# Altium schematic sheet Analog.SchDoc
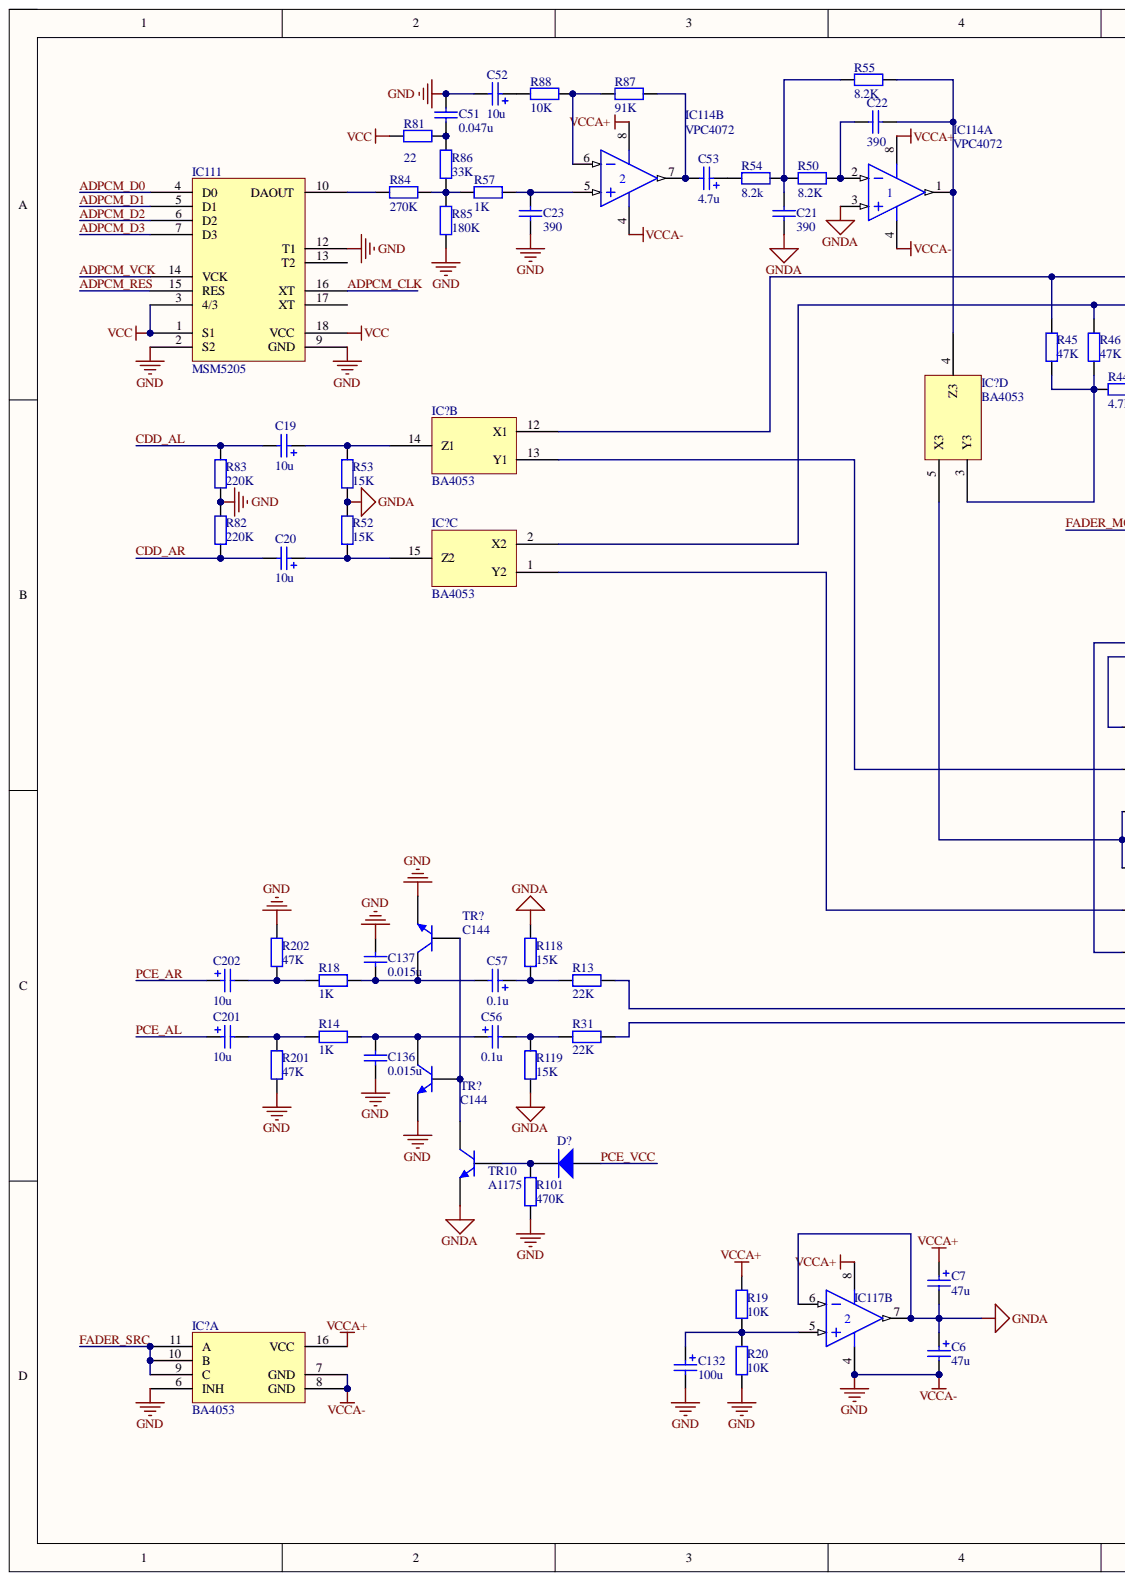
[diagram p0: Analog.SchDoc, left]
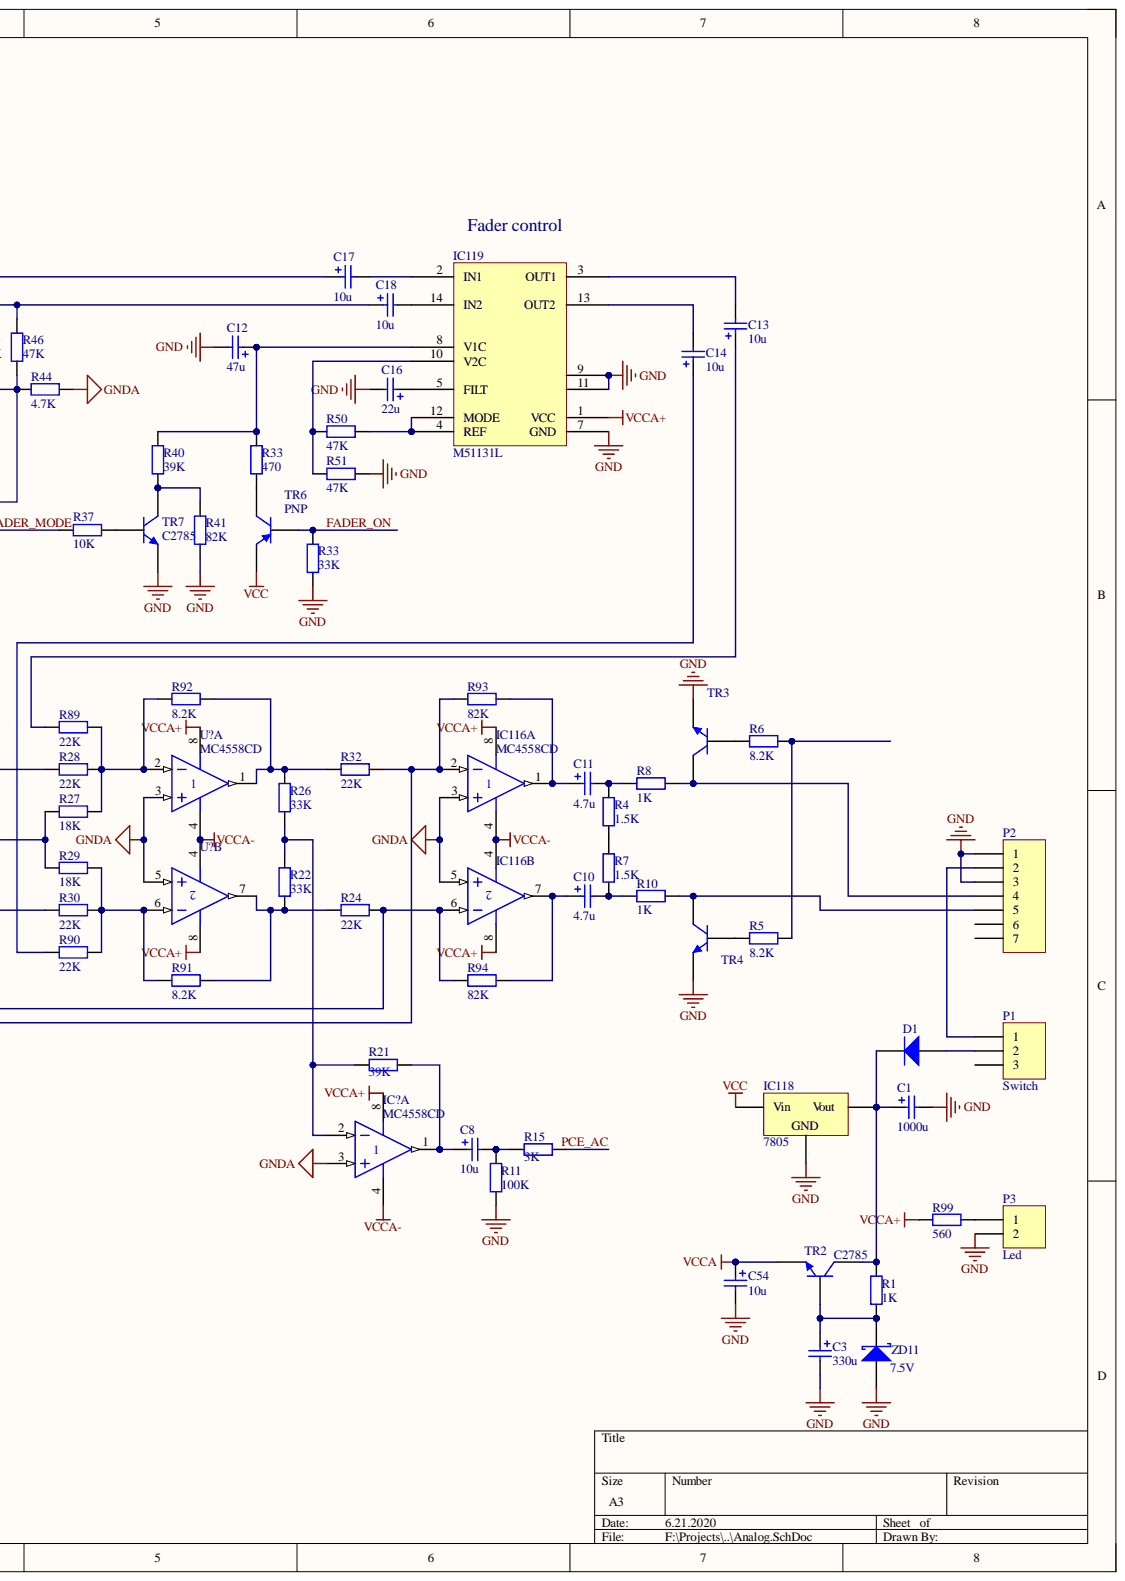
[diagram p1: Analog.SchDoc, right]

## Parts
C1  value 1000u  [POLAR0.8]  (hidden comment: Cap Pol2)
C3  value 330u  [POLAR0.8]  (hidden comment: Cap Pol2)
C6  value 47u  [POLAR0.8]  (hidden comment: Cap Pol2)
C7  value 47u  [POLAR0.8]  (hidden comment: Cap Pol2)
C8  value 10u  [POLAR0.8]  (hidden comment: Cap Pol2)
C10  value 4.7u  [POLAR0.8]  (hidden comment: Cap Pol2)
C11  value 4.7u  [POLAR0.8]  (hidden comment: Cap Pol2)
C12  value 47u  [POLAR0.8]  (hidden comment: Cap Pol2)
C13  value 10u  [POLAR0.8]  (hidden comment: Cap Pol2)
C14  value 10u  [POLAR0.8]  (hidden comment: Cap Pol2)
C16  value 22u  [POLAR0.8]  (hidden comment: Cap Pol2)
C17  value 10u  [POLAR0.8]  (hidden comment: Cap Pol2)
C18  value 10u  [POLAR0.8]  (hidden comment: Cap Pol2)
C19  value 10u  [POLAR0.8]  (hidden comment: Cap Pol2)
C20  value 10u  [POLAR0.8]  (hidden comment: Cap Pol2)
C21  value 390  [C1206]  (hidden comment: Cap Semi)
C22  value 390  [C1206]  (hidden comment: Cap Semi)
C23  value 390  [C1206]  (hidden comment: Cap Semi)
C51  value 0.047u  [C1206]  (hidden comment: Cap Semi)
C52  value 10u  [POLAR0.8]  (hidden comment: Cap Pol2)
C53  value 4.7u  [POLAR0.8]  (hidden comment: Cap Pol2)
C54  value 10u  [POLAR0.8]  (hidden comment: Cap Pol2)
C56  value 0.1u  [POLAR0.8]  (hidden comment: Cap Pol2)
C57  value 0.1u  [POLAR0.8]  (hidden comment: Cap Pol2)
C132  value 100u  [POLAR0.8]  (hidden comment: Cap Pol2)
C136  value 0.015u  [C1206]  (hidden comment: Cap Semi)
C137  value 0.015u  [C1206]  (hidden comment: Cap Semi)
C201  value 10u  [POLAR0.8]  (hidden comment: Cap Pol2)
C202  value 10u  [POLAR0.8]  (hidden comment: Cap Pol2)
D1  Diode 1N4148  [DO-35]  (value not drawn)
D?  Diode 1N4148  [DO-35]  (value not drawn)
IC111  MSM5205
IC114  VPC4072  [751-02_N]  (parts A,B)
IC116  MC4558CD  [751-02_N]  (parts A,B)
IC117  MC4558CD  [751-02_N]  (part B)
IC118  7805  [D2PAK_N]
IC119  M51131L
IC?  MC4558CD  [751-02_N]  (parts A,B,C,D)
IC?  BA4053  (part A)
P1  Switch  [HDR1X3]
P2  Header 7  [HDR1X7]  (value not drawn)
P3  Led  [HDR1X2H]
R1  value 1K  [AXIAL-0.4]  (hidden comment: Res2)
R4  value 1.5K  [AXIAL-0.4]  (hidden comment: Res2)
R5  value 8.2K  [AXIAL-0.4]  (hidden comment: Res2)
R6  value 8.2K  [AXIAL-0.4]  (hidden comment: Res2)
R7  value 1.5K  [AXIAL-0.4]  (hidden comment: Res2)
R8  value 1K  [AXIAL-0.4]  (hidden comment: Res2)
R10  value 1K  [AXIAL-0.4]  (hidden comment: Res2)
R11  value 100K  [AXIAL-0.4]  (hidden comment: Res2)
R13  value 22K  [AXIAL-0.4]  (hidden comment: Res2)
R14  value 1K  [AXIAL-0.4]  (hidden comment: Res2)
R15  value 3K  [AXIAL-0.4]  (hidden comment: Res2)
R18  value 1K  [AXIAL-0.4]  (hidden comment: Res2)
R19  value 10K  [AXIAL-0.4]  (hidden comment: Res2)
R20  value 10K  [AXIAL-0.4]  (hidden comment: Res2)
R21  value 39K  [AXIAL-0.4]  (hidden comment: Res2)
R22  value 33K  [AXIAL-0.4]  (hidden comment: Res2)
R24  value 22K  [AXIAL-0.4]  (hidden comment: Res2)
R26  value 33K  [AXIAL-0.4]  (hidden comment: Res2)
R27  value 18K  [AXIAL-0.4]  (hidden comment: Res2)
R28  value 22K  [AXIAL-0.4]  (hidden comment: Res2)
R29  value 18K  [AXIAL-0.4]  (hidden comment: Res2)
R30  value 22K  [AXIAL-0.4]  (hidden comment: Res2)
R31  value 22K  [AXIAL-0.4]  (hidden comment: Res2)
R32  value 22K  [AXIAL-0.4]  (hidden comment: Res2)
R33  value 33K  [AXIAL-0.4]  (hidden comment: Res2)
R33  value 470  [AXIAL-0.4]  (hidden comment: Res2)
R37  value 10K  [AXIAL-0.4]  (hidden comment: Res2)
R40  value 39K  [AXIAL-0.4]  (hidden comment: Res2)
R41  value 82K  [AXIAL-0.4]  (hidden comment: Res2)
R44  value 4.7K  [AXIAL-0.4]  (hidden comment: Res2)
R45  value 47K  [AXIAL-0.4]  (hidden comment: Res2)
R46  value 47K  [AXIAL-0.4]  (hidden comment: Res2)
R50  value 47K  [AXIAL-0.4]  (hidden comment: Res2)
R50  value 8.2K  [AXIAL-0.4]  (hidden comment: Res2)
R51  value 47K  [AXIAL-0.4]  (hidden comment: Res2)
R52  value 15K  [AXIAL-0.4]  (hidden comment: Res2)
R53  value 15K  [AXIAL-0.4]  (hidden comment: Res2)
R54  value 8.2k  [AXIAL-0.4]  (hidden comment: Res2)
R55  value 8.2K  [AXIAL-0.4]  (hidden comment: Res2)
R57  value 1K  [AXIAL-0.4]  (hidden comment: Res2)
R81  value 22  [AXIAL-0.4]  (hidden comment: Res2)
R82  value 220K  [AXIAL-0.4]  (hidden comment: Res2)
R83  value 220K  [AXIAL-0.4]  (hidden comment: Res2)
R84  value 270K  [AXIAL-0.4]  (hidden comment: Res2)
R85  value 180K  [AXIAL-0.4]  (hidden comment: Res2)
R86  value 33K  [AXIAL-0.4]  (hidden comment: Res2)
R87  value 91K  [AXIAL-0.4]  (hidden comment: Res2)
R88  value 10K  [AXIAL-0.4]  (hidden comment: Res2)
R89  value 22K  [AXIAL-0.4]  (hidden comment: Res2)
R90  value 22K  [AXIAL-0.4]  (hidden comment: Res2)
R91  value 8.2K  [AXIAL-0.4]  (hidden comment: Res2)
R92  value 8.2K  [AXIAL-0.4]  (hidden comment: Res2)
R93  value 82K  [AXIAL-0.4]  (hidden comment: Res2)
R94  value 82K  [AXIAL-0.4]  (hidden comment: Res2)
R99  value 560  [AXIAL-0.4]  (hidden comment: Res2)
R101  value 470K  [AXIAL-0.4]  (hidden comment: Res2)
R118  value 15K  [AXIAL-0.4]  (hidden comment: Res2)
R119  value 15K  [AXIAL-0.4]  (hidden comment: Res2)
R201  value 47K  [AXIAL-0.4]  (hidden comment: Res2)
R202  value 47K  [AXIAL-0.4]  (hidden comment: Res2)
TR2  C2785  [TO-226-AA]
TR3  (no value)  [TO-226-AA]
TR4  (no value)  [TO-226-AA]
TR6  PNP  [SOT-23B_N]
TR7  C2785  [TO-226-AA]
TR10  A1175  [TO-226-AA]
TR?  C144  [TO-226-AA]
TR?  C144  [TO-226-AA]
U?  MC4558CD  [751-02_N]  (parts A,B)
ZD11  7.5V  [SOT-23_N]

## Nets
GND: C1.2 C3.2 C6.2 C12.2 C16.2 C23.2 C51.2 C52.2 C54.2 C132.2 C136.2 C137.1 IC111.2(S2) IC111.9(GND) IC111.12(T1) IC114.4 IC116.4 IC117.4 IC118.2 IC119.7(GND) IC119.9 IC119.11 IC?.4 IC?.6(INH) IC?.7(GND) IC?.8 P2.1 P2.3 P3.2 R11.1 R20.1 R33.1 R41.1 R51.2 R82.2 R83.1 R85.1 R101.1 R201.1 R202.2 TR3.3 TR4.3 TR7.3 TR?.3 U?.4 ZD11.1
GNDA: C6.1 C7.2 C21.1 IC114.3 IC116.3 IC116.5 IC117.6 IC117.7 IC?.3 R44.2 R52.2 R53.1 R118.2 R119.1 TR10.3 U?.3 U?.5
VCC: IC111.1(S1) IC111.3(4/3) IC111.18(VCC) IC118.1 R81.1 TR6.3
VCCA: C54.1 TR2.3
VCCA+: C7.1 IC114.8 IC116.8 IC117.8 IC119.1(VCC) IC?.8 IC?.16(VCC) R19.2 R99.1 U?.8
ADPCM_CLK: IC111.16(XT)
ADPCM_D0: IC111.4(D0)
ADPCM_D1: IC111.5(D1)
ADPCM_D2: IC111.6(D2)
ADPCM_D3: IC111.7(D3)
ADPCM_RES: IC111.15(RES)
ADPCM_VCK: IC111.14(VCK)
CDD_AL: C19.2 R83.2
CDD_AR: C20.2 R82.1
FADER_MODE: R37.1
FADER_ON: R33.2 TR6.2
FADER_SRC: IC?.9(C) IC?.10(B) IC?.11(A)
PCE_AL: C201.1
PCE_AR: C202.1
PCE_VCC: D?.1
NetC1_1: C1.1 D1.2 IC118.3 R1.2 TR2.1
NetC3_1: C3.1 R1.1 TR2.2 ZD11.3
NetC8_1: C8.1 IC?.1 R21.2
NetC8_2: C8.2 R11.2 R15.1
NetC10_1: C10.1 IC116.7 R94.2
NetC10_2: C10.2 R7.1 R10.1
NetC11_1: C11.1 IC116.1 R93.2
NetC11_2: C11.2 R4.2 R8.1
NetC12_1: C12.1 IC119.8(V1C) R33.2 R40.2
NetC13_1: C13.1 R89.1
NetC13_2: C13.2 IC119.3(OUT1)
NetC14_1: C14.1 R90.1
NetC14_2: C14.2 IC119.13(OUT2)
NetC16_1: C16.1 IC119.5(FILT)
NetC17_1: C17.1 IC?.12(X1) R45.2
NetC17_2: C17.2 IC119.2(IN1)
NetC18_1: C18.1 IC?.2 R46.2
NetC18_2: C18.2 IC119.14(IN2)
NetC19_1: C19.1 IC?.14(Z1) R53.2
NetC20_1: C20.1 IC?.15(Z2) R52.1
NetC21_2: C21.2 R50.1 R54.2 R55.1
NetC22_1: C22.1 IC114.1 IC?.4 R55.2
NetC22_2: C22.2 IC114.2 R50.2
NetC23_1: C23.1 IC114.5 R57.2
NetC51_1: C51.1 R81.2 R86.2
NetC52_1: C52.1 R88.1
NetC53_1: C53.1 R54.1
NetC53_2: C53.2 IC114.7 R87.2
NetC56_1: C56.1 C136.1 R14.1 TR?.1
NetC56_2: C56.2 R31.2 R119.2
NetC57_1: C57.1 R13.2 R118.1
NetC57_2: C57.2 C137.2 R18.1 TR?.1
NetC132_1: C132.1 IC117.5 R19.1 R20.2
NetC201_2: C201.2 R14.2 R201.2
NetC202_2: C202.2 R18.2 R202.1
NetD1_1: D1.1 P1.2
NetD?_2: D?.2 R101.2 TR10.2
NetIC111_10: IC111.10(DAOUT) R84.1
NetIC114_6: IC114.6 R87.1 R88.2
NetIC116_2: IC116.2 R31.1 R32.2 R93.1
NetIC116_6: IC116.6 R13.1 R24.2 R94.1
NetIC119_4: IC119.4(REF) IC119.12(MODE) R50.2
NetIC119_10: IC119.10(V2C) R50.1 R51.1
NetIC?_1: IC?.1 R30.1
NetIC?_2: IC?.2 R21.1 R22.2 R26.1
NetIC?_3: IC?.3 R44.1 R45.1 R46.1
NetIC?_5: IC?.5 R27.1 R29.1
NetIC?_13: IC?.13(Y1) R28.1
NetP1_1: P1.1 P2.2
NetP2_4: P2.4 R8.2 TR3.1
NetP2_5: P2.5 R10.2 TR4.1
NetP3_1: P3.1 R99.2
NetR4_1: R4.1 R7.2
NetR5_1: R5.1 TR4.2
NetR5_2: R5.2 R6.2
NetR6_1: R6.1 TR3.2
NetR22_1: R22.1 R24.1 R91.2 U?.7
NetR26_2: R26.2 R32.1 R92.2 U?.1
NetR27_2: R27.2 R28.2 R89.2 R92.1 U?.2
NetR29_2: R29.2 R30.2 R90.2 R91.1 U?.6
NetR33_1: R33.1 TR6.1
NetR37_2: R37.2 TR7.2
NetR40_1: R40.1 R41.2 TR7.1
NetR57_1: R57.1 R84.2 R85.2 R86.1
NetTR10_1: TR10.1 TR?.2
PCE_AC:

Not connected: IC111 13(T2) 17(XT); P1 3; P2 6 7; R15 2

## Library data of the parts
C1 C3 C6-C8 C10-C14 C16-C20 C52-C54 C56 C57 C132 C201 C202 = Cap Pol2: Polarized Capacitor (Axial); Component Kind: Standard; LatestRevisionDate: 17-Jul-2002; LatestRevisionNote: Re-released for DXP Platform.; Library Name: Miscellaneous Devices.IntLib; PackageDescription: Polarized Capacitor; 2 Leads; PackageReference: POLAR0.8; Published: 8-Jun-2000; Signal Integrity: Cap Pol; Simulation: CAP; Publisher: Altium Limited
C21-C23 C51 C136 C137 = Cap Semi: Capacitor (Semiconductor SIM Model); Component Kind: Standard; LatestRevisionDate: 29-May-2009; LatestRevisionNote: IPC-7351 Footprint Added.; Library Name: Miscellaneous Devices.IntLib; PackageDescription: Chip Capacitor; PackageReference: C1206; Published: 8-Jun-2000; Signal Integrity: Cap Semi; Simulation: CAP; Publisher: Altium Limited
D1 D? = Diode 1N4148: High Conductance Fast Diode; Code_JEDEC: DO-204-AH; Component Kind: Standard; ComponentLink1Description: Manufacturer Link; ComponentLink1URL: http://www.fairchildsemi.com/; ComponentLink2Description: Datasheet; ComponentLink2URL: http://www.fairchildsemi.com/ds/1N%2F1N4148.pdf; DatasheetVersion: 1997; LatestRevisionDate: 17-Jul-2002; LatestRevisionNote: Re-released for DXP Platform.; Library Name: Miscellaneous Devices.IntLib; PackageDescription: Diode, Axial; Body 3.8 x 1.9 mm (LxDia. max), Lead Dia. 0.53 mm (max); PackageReference: DO-35; Published: 8-Jun-2000; Signal Integrity: 1N4148; Simulation: 1N4148; Publisher: Altium Limited
IC114 IC116 IC117 IC? U? = MC4558CD: Dual High-Frequency Operational Amplifier; Code_IPC: SOIC127P600-8; Code_JEDEC: MS-012-AA; Component Kind: Standard; ComponentLink1Description: Manufacturer Link; ComponentLink1URL: http://www.onsemi.com/site/products/parametrics/0,4446,251,00.html; DatasheetVersion: 1988; LatestRevisionDate: 13-Apr-2006; LatestRevisionNote: IPC-7351 Footprint Added.; Library Name: Motorola Amplifier Operational Amplifier.IntLib; PackageDescription: 8-Pin Small Outline Integrated Circuit 1.27 mm Pitch; PackageReference: 751-02; PCB3D: 751-02; Published: 17-Jul-2002; Simulation: MC4558; Publisher: Altium Limited
IC118 = Volt Reg: Voltage Regulator; Component Kind: Standard; LatestRevisionDate: 29-May-2009; LatestRevisionNote: IPC-7351 Footprint Added.; Library Name: Miscellaneous Devices.IntLib; PackageDescription: 3-Pin Transistor Outline Package 2.54 mm Pitch, 15.1 mm Lead Span; PackageReference: D2PAK; Published: 24-Mar-1999; Publisher: Altium Limited
P1 = Header 3: Header, 3-Pin; Component Kind: Standard; LatestRevisionDate: 17-Jul-2002; LatestRevisionNote: Re-released for DXP Platform.; Library Name: Miscellaneous Connectors.IntLib; Signal Integrity: Connector; Publisher: Altium Limited
P2 = Header 7: Header, 7-Pin; Component Kind: Standard; LatestRevisionDate: 17-Jul-2002; LatestRevisionNote: Re-released for DXP Platform.; Library Name: Miscellaneous Connectors.IntLib; Signal Integrity: Connector; Publisher: Altium Limited
P3 = Header 2H: Header, 2-Pin, Right Angle; Component Kind: Standard; LatestRevisionDate: 17-Jul-2002; LatestRevisionNote: Re-released for DXP Platform.; Library Name: Miscellaneous Connectors.IntLib; Signal Integrity: Connector; Publisher: Altium Limited
R1 R4-R8 R10 R11 R13-R15 R18-R22 R24 R26-R33 R33 R37 R40 R41 R44-R46 R50 R50-R55 R57 R81-R94 R99 R101 R118 R119 R201 R202 = Res2: Resistor; Component Kind: Standard; LatestRevisionDate: 17-Jul-2002; LatestRevisionNote: Re-released for DXP Platform.; Library Name: Miscellaneous Devices.IntLib; PackageDescription: Axial Device, Thru-Hole; 2 Leads; 0.4 in Pin Spacing; PackageReference: AXIAL-0.4; Published: 8-Jun-2000; Signal Integrity: Res; Simulation: RESISTOR; Publisher: Altium Limited
TR2-TR4 TR7 TR10 TR? TR? = NPN: NPN Bipolar Transistor; Code_JEDEC: TO-226-AA; Component Kind: Standard; LatestRevisionDate: 17-Jul-2002; LatestRevisionNote: Re-released for DXP Platform.; Library Name: Miscellaneous Devices.IntLib; PackageDescription: TO, Flat Index; 3 In-Line, Axial Leads; Body Dia. 4.8mm; Leads 0.55 x 0.51 mm (max); PackageReference: TO-226-AA; Published: 8-Jun-2000; Signal Integrity: NPN; Simulation: NPN; Publisher: Altium Limited
TR6 = PNP: PNP Bipolar Transistor; Component Kind: Standard; LatestRevisionDate: 29-May-2009; LatestRevisionNote: IPC-7351 Footprint Added.; Library Name: Miscellaneous Devices.IntLib; PackageDescription: 3-Pin SOT-23 Package 0.95 mm Pitch, 2.4 mm Lead Span; PackageReference: SOT-23B; PackageVersion: Aug-1999; Published: 8-Jun-2000; Signal Integrity: PNP; Simulation: PNP; Publisher: Altium Limited
ZD11 = Diode BAS70: Silicon AF Schottky Diode for High-Speed Switching; Note: Pin 2 is not connected and not drawn.; Component Kind: Standard; ComponentLink1Description: Manufacturer Link; ComponentLink1URL: http://www.infineon.com/; ComponentLink2Description: Datasheet; ComponentLink2URL: http://www.infineon.com/upload/Document/cmc_upload/documents/009/382/bas40_bas140series_1.pdf; DatasheetVersion: Oct-1999; LatestRevisionDate: 29-May-2009; LatestRevisionNote: IPC-7351 Footprint Added.; Library Name: Miscellaneous Devices.IntLib; PackageDescription: 3-Pin SOT-23 Package 0.95 mm Pitch, 2.5 mm Lead Span; PackageReference: SOT-23; PackageVersion: Sep-2001; Published: 21-Mar-2003; Simulation: BAS70; Publisher: Altium Limited
IC111 = MSM5205: Component Kind: Standard; Library Name: Schlib.SchLib
IC119 = M51131L: Component Kind: Standard; Library Name: Schlib.SchLib
IC? = BA4053: Component Kind: Standard; Library Name: Schlib.SchLib
